# Altium schematic sheet Power_Supply2.SchDoc(Power_Supply2)
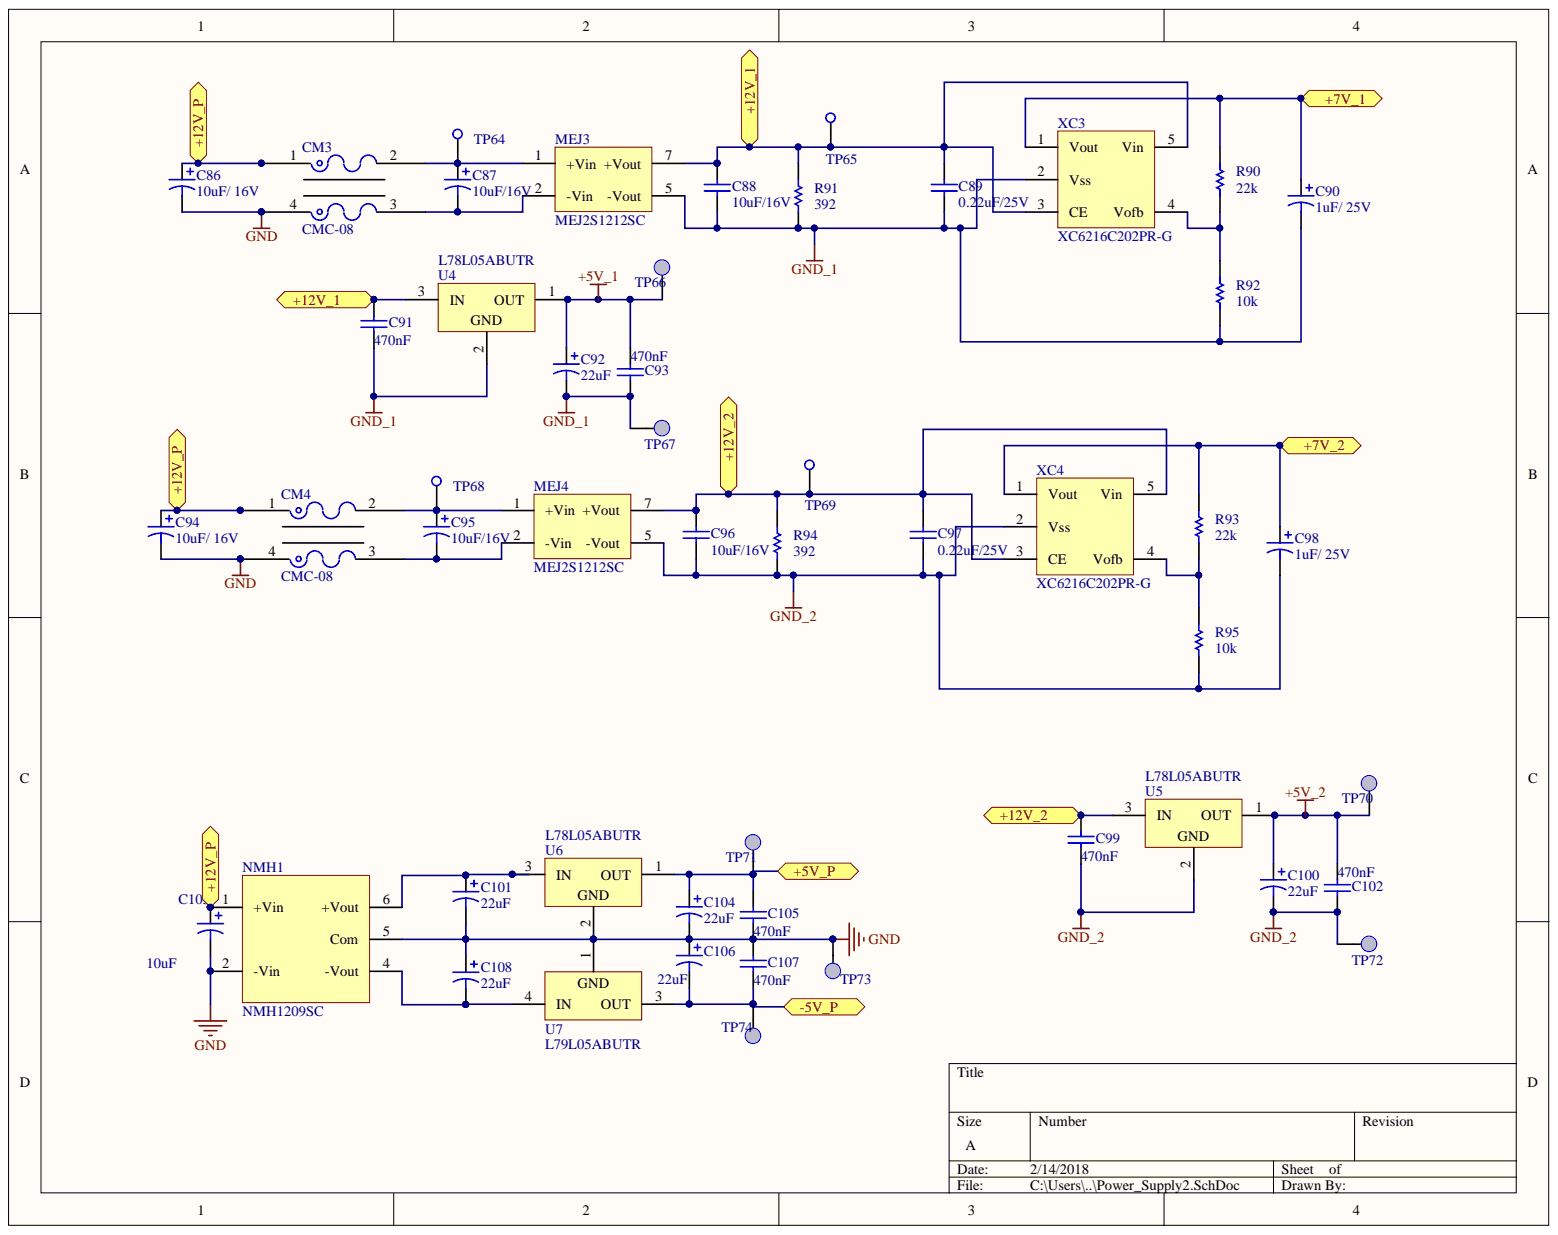
[diagram p0: Power_Supply2.SchDoc]

## Parts
C86  value 10uF/ 16V  [1812]  (hidden comment: Cap Pol3)
C87  value 10uF/16V  [1812]  (hidden comment: Cap Pol3)
C88  value 10uF/16V  [C1206]  (hidden comment: Cap Semi)
C89  value 0.22uF/25V  [C1206]  (hidden comment: Cap Semi)
C90  value 1uF/ 25V  [C0805]  (hidden comment: Cap Pol3)
C91  value 470nF  [Capacitor 0805]  (hidden comment: Cap)
C92  value 22uF  [Cap Tantal 3216]  (hidden comment: Tantalium/T520A226M006ATE090)
C93  value 470nF  [Capacitor 0805]  (hidden comment: Cap)
C94  value 10uF/ 16V  [1812]  (hidden comment: Cap Pol3)
C95  value 10uF/16V  [1812]  (hidden comment: Cap Pol3)
C96  value 10uF/16V  [C1206]  (hidden comment: Cap Semi)
C97  value 0.22uF/25V  [C1206]  (hidden comment: Cap Semi)
C98  value 1uF/ 25V  [C0805]  (hidden comment: Cap Pol3)
C99  value 470nF  [Capacitor 0805]  (hidden comment: Cap)
C100  value 22uF  [Cap Tantal 3216]  (hidden comment: Tantalium/T520A226M006ATE090)
C101  value 22uF  [Cap tantal 22u-25V]  (hidden comment: Tantalium)
C102  value 470nF  [Capacitor 0805]  (hidden comment: Cap)
C103  value 10uF  [CAP-10u-16V]  (hidden comment: electrolytic)
C104  value 22uF  [Cap Tantal 3216]  (hidden comment: Tantalium/T520A226M006ATE090)
C105  value 470nF  [Capacitor 0805]  (hidden comment: Cap)
C106  value 22uF  [Cap Tantal 3216]  (hidden comment: Tantalium/T520A226M006ATE090)
C107  value 470nF  [Capacitor 0805]  (hidden comment: Cap)
C108  value 22uF  [Cap tantal 22u-25V]  (hidden comment: Tantalium)
CM3  CMC-08  [CMC-08]
CM4  CMC-08  [CMC-08]
MEJ3  MEJ2S1212SC  [MEJ2]
MEJ4  MEJ2S1212SC  [MEJ2]
NMH1  NMH1209SC  [NMH1209SC]
R90  res_smd  [res_smd]  (value not drawn)
R91  res_smd  [res_smd]  (value not drawn)
R92  res_smd  [res_smd]  (value not drawn)
R93  res_smd  [res_smd]  (value not drawn)
R94  res_smd  [res_smd]  (value not drawn)
R95  res_smd  [res_smd]  (value not drawn)
TP64  Test Point  [Test Point]  (value not drawn)
TP65  Test Point  [Test Point]  (value not drawn)
TP66  Test point  [Test Point]  (value not drawn)
TP67  Test point  [Test Point]  (value not drawn)
TP68  Test Point  [Test Point]  (value not drawn)
TP69  Test Point  [Test Point]  (value not drawn)
TP70  Test point  [Test Point]  (value not drawn)
TP71  Test point  [Test Point]  (value not drawn)
TP72  Test point  [Test Point]  (value not drawn)
TP73  Test point  [Test Point]  (value not drawn)
TP74  Test point  [Test Point]  (value not drawn)
U4  L78L05ABUTR  [Reg SOT-89 +5]
U5  L78L05ABUTR  [Reg SOT-89 +5]
U6  L78L05ABUTR  [Reg SOT-89 +5]
U7  L79L05ABUTR  [Reg SOT-89]
XC3  XC6216C202PR-G  [SOT-89-5]
XC4  XC6216C202PR-G  [SOT-89-5]

## Ports
+5V_P, +7V_1, +7V_2, +12V_1, +12V_2, +12V_P, -5V_P

## Nets
+5V_1: C92.1 C93.2 TP66.1 U4.1
+5V_2: C100.1 C102.2 TP70.1 U5.1
GND: C86.2 C94.2 C101.2 C103.2 C104.2 C105.1 C106.1 C107.2 C108.1 CM3.4 CM4.4 NMH1.2(-Vin) NMH1.5(Com) TP73.1 U6.2 U7.1
GND_1: C88.1 C89.1 C90.2 C91.1 C92.2 C93.1 MEJ3.5(-Vout) R91.2 R92.2 TP67.1 U4.2 XC3.2(Vss)
GND_2: C96.1 C97.1 C98.2 C99.1 C100.2 C102.1 MEJ4.5(-Vout) R94.2 R95.2 TP72.1 U5.2 XC4.2(Vss)
+7V_1: C90.1 R90.1 XC3.1(Vout) ; port +7V_1
+7V_2: C98.1 R93.1 XC4.1(Vout) ; port +7V_2
+12V_1: C88.2 C89.2 C91.2 MEJ3.7(+Vout) R91.1 TP65.1 U4.3 XC3.3(CE) XC3.5(Vin) ; port +12V_1
+12V_2: C96.2 C97.2 C99.2 MEJ4.7(+Vout) R94.1 TP69.1 U5.3 XC4.3(CE) XC4.5(Vin) ; port +12V_2
+12V_P: C86.1 C94.1 C103.1 CM3.1 CM4.1 NMH1.1(+Vin) ; port +12V_P
NetC87_1: C87.1 CM3.2 MEJ3.1(+Vin) TP64.1
NetC87_2: C87.2 CM3.3 MEJ3.2(-Vin)
NetC95_1: C95.1 CM4.2 MEJ4.1(+Vin) TP68.1
NetC95_2: C95.2 CM4.3 MEJ4.2(-Vin)
NetC101_1: C101.1 NMH1.6(+Vout) U6.3
NetC104_1: C104.1 C105.2 TP71.1 U6.1
NetC106_2: C106.2 C107.1 TP74.1 U7.3
NetC108_2: C108.2 NMH1.4(-Vout) U7.4
NetR90_2: R90.2 R92.1 XC3.4(Vofb)
NetR93_2: R93.2 R95.1 XC4.4(Vofb)

## Library data of the parts
C86 C94 = Cap Pol3: Polarized Capacitor (Surface Mount); Value: 10uF/ 16V; Simulation: CAP; Signal Integrity: Cap Pol; Published: 8-Jun-2000; PackageReference: C0805; PackageDescription: Chip Capacitor; Library Name: Miscellaneous Devices.SchLib; LatestRevisionNote: IPC-7351 Footprint Added.; LatestRevisionDate: 29-May-2009; Component Kind: Standard; Publisher: Altium Limited
C87 C90 C95 C98 = Cap Pol3: Polarized Capacitor (Surface Mount); Simulation: CAP; Signal Integrity: Cap Pol; Published: 8-Jun-2000; PackageReference: C0805; PackageDescription: Chip Capacitor; Library Name: Miscellaneous Devices.SchLib; LatestRevisionNote: IPC-7351 Footprint Added.; LatestRevisionDate: 29-May-2009; Component Kind: Standard; Publisher: Altium Limited
C88 C89 C96 C97 = Cap Semi: Capacitor (Semiconductor SIM Model); Simulation: CAP; Signal Integrity: Cap Semi; Published: 8-Jun-2000; PackageReference: C1206; PackageDescription: Chip Capacitor; Library Name: Miscellaneous Devices.SchLib; LatestRevisionNote: IPC-7351 Footprint Added.; LatestRevisionDate: 29-May-2009; Component Kind: Standard; Publisher: Altium Limited
C91 C93 C99 C102 C105 C107 = Cap: Capacitor; Simulation: CAP; Signal Integrity: Cap; Published: 8-Jun-2000; PackageReference: RAD-0.3; Library Name: Miscellaneous Devices.IntLib; LatestRevisionNote: Re-released for DXP Platform.; LatestRevisionDate: 17-Jul-2002; Component Kind: Standard; Publisher: Altium Limited
C92 C100 C101 C103 C104 C106 C108 = Cap Pol1: Polarized Capacitor (Radial); Simulation: CAP; Signal Integrity: Cap Pol; Published: 8-Jun-2000; PackageReference: RB7.6-15; Library Name: Miscellaneous Devices.IntLib; LatestRevisionNote: Re-released for DXP Platform.; LatestRevisionDate: 17-Jul-2002; Component Kind: Standard; Publisher: Altium Limited
CM3 CM4 = CMC-08: Common mode choke; Component Kind: Standard; Library Name: lib_ankit.SchLib
MEJ3 MEJ4 = Power supply2: Component Kind: Standard; Library Name: Gan_cuk converter.SchLib
NMH1 = NMH----SC_1: Library Name: control Board.SchLib; Component Kind: Standard
R90-R95 = res_smd: smd_res; Library Name: Schlib1.SchLib; Component Kind: Standard
  R90 R93: : 22k
  R91 R94: : 392
  R92 R95: : 10k
U4-U6 = L78L05ABZ: Positive Voltage Regulator; Published: 24-Aug-2001; PackageReference: TO92; PackageDescription: TO, Flat Index; 3 In-Line, Axial Leads; Body Dia. 4.8mm; Leads 0.51 x 0.51 mm (max); Library Name: ST Power Mgt Voltage Regulator.IntLib; LatestRevisionNote: Re-released for DXP Platform.; LatestRevisionDate: 17-Jul-2002; DatasheetVersion: Feb-1999; ComponentLink1URL: http://www.st.com/; ComponentLink1Description: Manufacturer Link; Component Kind: Standard; Publisher: Altium Limited
U7 = µA79M05CKTPR: Negative-Voltage Regulator; Note: Pin 2 is electrically connected to pin4, and not drawn.; Published: 15-Apr-2002; PackageVersion: Jan-2001; PackageReference: KTP02; PackageDescription: PowerFLEX (D-PAK/TO-252-AA); 3 Leads (1 Cropped); Body 9.7 (inc. leads) x 6.2 mm (LxW max); Library Name: TI Power Mgt Voltage Regulator.IntLib; DatasheetVersion: Apr-2000; ComponentLink2URL: http://www-s.ti.com/sc/ds/ua79m05.pdf; ComponentLink2Description: Datasheet; ComponentLink1URL: http://www.ti.com/; ComponentLink1Description: Manufacturer Link; Component Kind: Standard; Code_JEDEC: TO-252; Publisher: Altium Limited
XC3 XC4 = power supply: Component Kind: Standard; Library Name: Gan_cuk converter.SchLib
TP64 TP65 TP68 TP69 = Test Point: Component Kind: Standard; Library Name: Gan_cuk converter.SchLib
TP66 TP67 TP70-TP74 = Test point: Library Name: CUK1.SchLib; Component Kind: Standard
